# Altium schematic sheet StepGeneratorHammondFrontPnlRev1b..SchDoc(StepGeneratorHammondFrontPnlRev1b.)
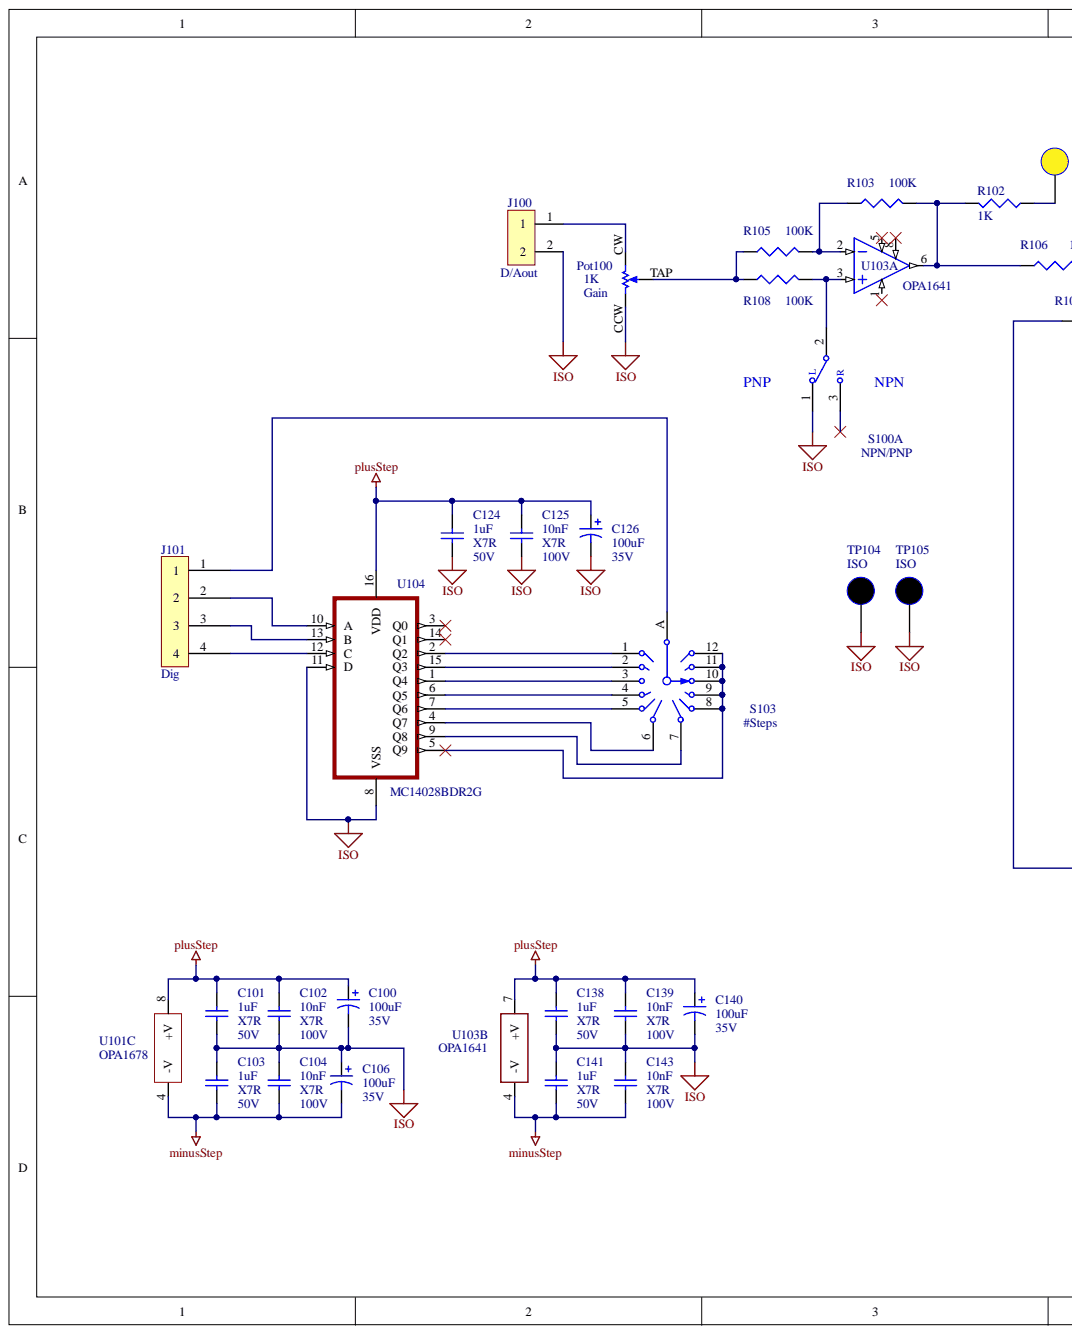
[diagram p0: StepGeneratorHammondFrontPnlRev1b..SchDoc, left]
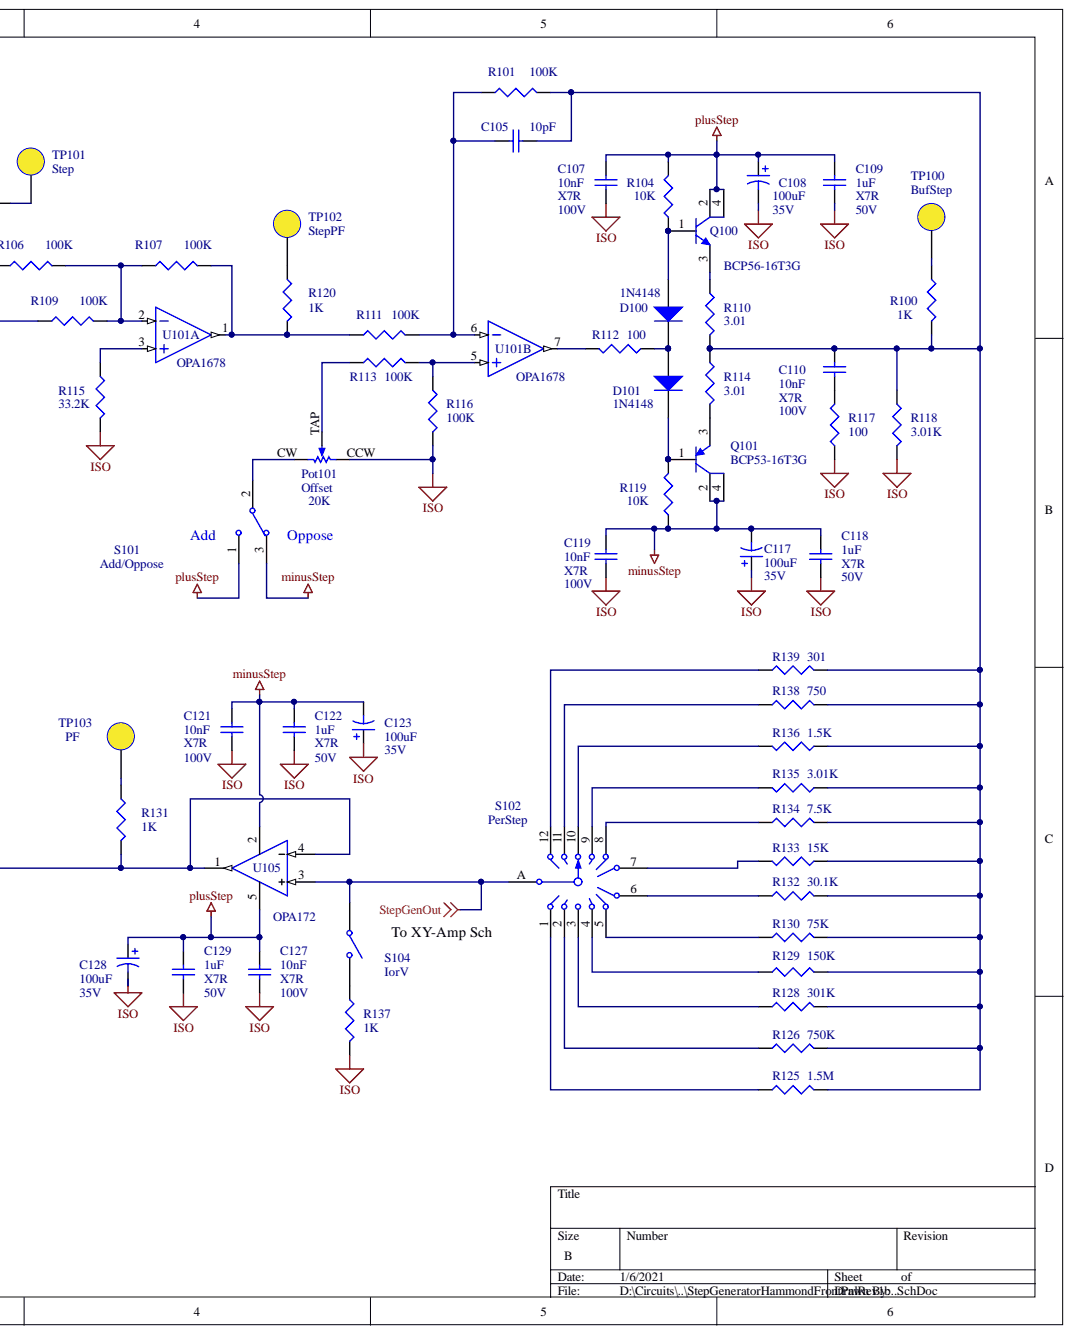
[diagram p1: StepGeneratorHammondFrontPnlRev1b..SchDoc, right]

## Parts
C100  100uF  Voltage Rating: 35V  [CAPPR2.5-6.3X10]
C101  1uF  Voltage Rating (DC): 50V  [CAPC3216M]
C102  10nF  Voltage Rating (DC): 100V  Dielectric: X7R  [CAPC3216M]
C103  1uF  Voltage Rating (DC): 50V  [CAPC3216M]
C104  10nF  Dielectric: X7R  [CAPC3216M]
C105  value 10pF  [CAPC3216M]  (hidden comment: Cap)
C106  100uF  Voltage Rating: 35V  [CAPPR2.5-6.3X10]
C107  10nF  Voltage Rating (DC): 100V  Dielectric: X7R  [CAPC3216M]
C108  100uF  Voltage Rating: 35V  [CAPPR2.5-6.3X10]
C109  1uF  Voltage Rating (DC): 50V  Dielectric: X7R  [CAPC3216M]
C110  10nF  Voltage Rating (DC): 100V  Dielectric: X7R  [CAPC3216M]
C117  100uF  Voltage Rating: 35V  [CAPPR2.5-6.3X10]
C118  1uF  Voltage Rating (DC): 50V  Dielectric: X7R  [CAPC3216M]
C119  10nF  Voltage Rating (DC): 100V  Dielectric: X7R  [CAPC3216M]
C121  10nF  Voltage Rating (DC): 100V  Dielectric: X7R  [CAPC3216M]
C122  1uF  Voltage Rating (DC): 50V  Dielectric: X7R  [CAPC3216M]
C123  100uF  Voltage Rating: 35V  [CAPPR2.5-6.3X10]
C124  1uF  Voltage Rating (DC): 50V  Dielectric: X7R  [CAPC3216M]
C125  10nF  Voltage Rating (DC): 100V  Dielectric: X7R  [CAPC3216M]
C126  100uF  Voltage Rating: 35V  [CAPPR2.5-6.3X10]
C127  10nF  Voltage Rating (DC): 100V  Dielectric: X7R  [CAPC3216M]
C128  100uF  Voltage Rating: 35V  [CAPPR2.5-6.3X10]
C129  1uF  Voltage Rating (DC): 50V  Dielectric: X7R  [CAPC3216M]
C138  1uF  Voltage Rating (DC): 50V  Dielectric: X7R  [CAPC3216M]
C139  10nF  Voltage Rating (DC): 100V  Dielectric: X7R  [CAPC3216M]
C140  100uF  Voltage Rating: 35V  [CAPPR2.5-6.3X10]
C141  1uF  Voltage Rating (DC): 50V  Dielectric: X7R  [CAPC3216M]
C143  10nF  Voltage Rating (DC): 100V  Dielectric: X7R  [CAPC3216M]
D100  1N4148  [SOD123]
D101  1N4148  [SOD123]
J100  D/Aout  [DTA-100-2]
J101  Dig  [DTA-100-4]
Pot100  Gain  value 1K  [3306P-CCW]
Pot101  Offset  value 20K  [Off-PCB]
Q100  Manufacturer Part Number: BCP56-16T3G  [SOT223-4M]  (hidden comment: NPN-2C)
Q101  Manufacturer Part Number: BCP53-16T3G  [SOT223-4M]  (hidden comment: PNP-2C)
R100  1K  [RESC3216M]
R101  100k  [RESC3216M]
R102  1K  [RESC3216M]
R103  100K  [RESC3216M]
R104  10K  [RESC3216M]
R105  100k  [RESC3216M]
R106  100k  [RESC3216M]
R107  100k  [RESC3216M]
R108  100k  [RESC3216M]
R109  100k  [RESC3216M]
R110  value 3.01  [RESC3216M]  (hidden comment: 10)
R111  100k  [RESC3216M]
R112  100  [RESC3216M]
R113  100k  [RESC3216M]
R114  value 3.01  [RESC3216M]  (hidden comment: 10)
R115  value 33.2K  [RESC3216M]  (hidden comment: 3.32KXXK)
R116  100k  [RESC3216M]
R117  100  [RESC3216M]
R118  value 3.01K  [RESC3216M]  (hidden comment: 3K)
R119  10K  [RESC3216M]
R120  1K  [RESC3216M]
R125  1.5M  [RESC3216M]
R126  750K  [RESC3216M]
R128  301K  [RESC3216M]
R129  150K  [RESC3216M]
R130  75K  [RESC3216M]
R131  1K  [RESC3216M]
R132  30.1K  [RESC3216M]
R133  15K  [RESC3216M]
R134  7.5K  [RESC3216M]
R135  value 3.01K  [RESC3216M]  (hidden comment: 3K)
R136  1.5K  [RESC3216M]
R137  1K  [RESC3216M]
R138  750  [RESC6432M]
R139  301  [RESC6432M]
S100  NPN/PNP  [EG2424]  (part A)
S101  Add/Oppose  [EG2375]
S102  PerStep  [A112]
S103  #Steps  [A112]
S104  IorV  [EG2375]
TP100  BufStep  [TP-.038]
TP101  Step  [TP-.038]
TP102  StepPF  [TP-.038]
TP103  PF  [TP-.038]
TP104  ISO  [TP-.038]
TP105  ISO  [TP-.038]
U101  OPA1678  [SOIC127P600X168_HS-8N]  (parts A,B,C)
U103  OPA1641  [SOIC127P600X168_HS-8N]  (parts A,B)
U104  MC14028BDR2G  [D16-M]
U105  OPA172  [SOT23-5AM]

## Nets
ISO: C100.2 C101.2 C102.1 C103.1 C104.2 C106.1 C107.1 C108.2 C109.2 C117.1 C118.2 C119.1 C121.1 C122.2 C123.1 C124.2 C125.1 C126.2 C127.1 C128.2 C129.2 C138.2 C139.1 C140.2 C141.1 C143.2 J100.2 Pot100.CCW Pot101.CCW R115.2 R116.2 R117.2 R118.2 R137.2 S100.1(L) TP104.1 TP105.1 U104.8(VSS) U104.11(D)
minusStep: C103.2 C104.1 C106.2 C117.2 C118.1 C119.2 C121.2 C122.1 C123.2 C141.2 C143.1 Q101.2 Q101.4 R119.2 S101.3 U101.4(-V) U103.4(-V) U105.2
plusStep: C100.1 C101.1 C102.2 C107.2 C108.1 C109.1 C124.1 C125.2 C126.1 C127.2 C128.1 C129.1 C138.1 C139.2 C140.1 Q100.2 Q100.4 R104.1 S101.1 U101.8(+V) U103.7(+V) U104.16(VDD) U105.5
StepGenOut: S102.A S104.1 U105.3
NetC105_1: C105.1 R101.1 R111.2 U101.6
NetC105_2: C105.2 C110.2 R100.2 R101.2 R110.2 R114.1 R118.1 R125.2 R126.2 R128.2 R129.2 R130.2 R132.2 R133.2 R134.2 R135.1 R136.2 R138.2 R139.2
NetC110_1: C110.1 R117.1
NetD100_1: D100.1 Q100.1 R104.2
NetD100_2: D100.2 D101.1 R112.2
NetD101_2: D101.2 Q101.1 R119.1
NetJ100_1: J100.1 Pot100.CW
NetJ101_1: J101.1 S103.A
NetJ101_2: J101.2 U104.10(A)
NetJ101_3: J101.3 U104.13(B)
NetJ101_4: J101.4 U104.12(C)
NetPot100_TAP: Pot100.TAP R105.1 R108.1
NetPot101_CW: Pot101.CW S101.2
NetPot101_TAP: Pot101.TAP R113.1
NetQ100_3: Q100.3 R110.1
NetQ101_3: Q101.3 R114.2
NetR100_1: R100.1 TP100.1
NetR102_1: R102.1 TP101.1
NetR102_2: R102.2 R103.2 R106.1 U103.6
NetR103_1: R103.1 R105.2 U103.2
NetR106_2: R106.2 R107.1 R109.2 U101.2
NetR107_2: R107.2 R111.1 R120.2 U101.1
NetR108_2: R108.2 S100.2 U103.3
NetR109_1: R109.1 R131.2 U105.1 U105.4
NetR112_1: R112.1 U101.7
NetR113_2: R113.2 R116.1 U101.5
NetR115_1: R115.1 U101.3
NetR120_1: R120.1 TP102.1
NetR125_1: R125.1 S102.1
NetR126_1: R126.1 S102.2
NetR128_1: R128.1 S102.3
NetR129_1: R129.1 S102.4
NetR130_1: R130.1 S102.5
NetR131_1: R131.1 TP103.1
NetR132_1: R132.1 S102.6
NetR133_1: R133.1 S102.7
NetR134_1: R134.1 S102.8
NetR135_2: R135.2 S102.9
NetR136_1: R136.1 S102.10
NetR137_1: R137.1 S104.2
NetR138_1: R138.1 S102.11
NetR139_1: R139.1 S102.12
NetS103_1: S103.1 U104.2(Q2)
NetS103_2: S103.2 U104.15(Q3)
NetS103_3: S103.3 U104.1(Q4)
NetS103_4: S103.4 U104.6(Q5)
NetS103_5: S103.5 U104.7(Q6)
NetS103_6: S103.6 U104.4(Q7)
NetS103_7: S103.7 U104.9(Q8)
NetS103_8: S103.8 S103.9 S103.10 S103.11 S103.12 U104.5(Q9)

Not connected: S100 3(R); U103 1 5 8; U104 3(Q0) 14(Q1)

## Library data of the parts
C100 C106 C108 C117 C123 C126 C128 C140 = Cap Pol1: CAP ALUM 100UF 20% 35V THRU HOLE; Manufacturer Part Number: 35YXJ100M6.3X11; Manufacturer: Rubycon; Ripple Current: 400mA; Polarity: Polar; Life (Hours): 7000Hour; Leakage Current: 3uA; Lead Diameter: 0.5mm; Impedance: 220mR; Voltage: 35V; Value: 100uF; Tolerance: 20%; Termination: Radial; Supplier Part Number: 1189-2260-ND; Supplier: Digi-Key; Simulation: CAP; Signal Integrity: Cap Pol; Radiation Hardening: No; Packaging: Bulk; Package Quantity: 3000; Mount: Through Hole; Min Operating Temperature: -40°C; Max Operating Temperature: 105°C; Library Name: Miscellaneous Devices.IntLib; Lead Pitch: 2.5mm; Height - Seated (Max): 0.492inch; Height: 11mm; Diameter: 6.3mm; Component Kind: Standard; Case/Package: Radial; Capacitance: 100uF
C101 C103 C109 C118 C122 C124 C129 C138 C141 = Cap: CAP CER 1UF 50V X7R 1206; Manufacturer Part Number: C1206C105K5RACTU; Manufacturer: KEMET; Tolerance: 10%; Dielectric Material: Ceramic; Width: 0.063inch; Voltage Rating: 50V; Voltage: 50V; Value: 1uF; Thickness: 0.043inch; Termination: SMD/SMT; Supplier Part Number: 399-8147-6-ND; Supplier: Digi-Key; Simulation: CAP; Signal Integrity: Cap; Series: C; REACH SVHC: No SVHC; Radiation Hardening: No; Packaging: Tape and Reel; Package Quantity: 1; Number of Pins: 2; Mount: Surface Mount; Min Operating Temperature: -55°C; Max Operating Temperature: 125°C; Library Name: Miscellaneous Devices.IntLib; Length: 3.2mm; Height: 1mm; Depth: 1.6mm; Construction: Flat; Component Kind: Standard; Case Code (Metric): 3216; Case Code (Imperial): 1206; Case/Package: 1206; Capacitance: 1uF
  C101 C103: Dielectric: X7R
C102 C104 C107 C110 C119 C121 C125 C127 C139 C143 = Cap: CAP CER 10NF 100V X7R 1206; Manufacturer Part Number: C1206C103K1RACTU; Manufacturer: KEMET; Tolerance: 10%; Dissipation Factor: 2.5%; Dielectric Material: Ceramic; Width: 0.063inch; Voltage Rating: 100V; Voltage: 100V; Value: 10nF; Thickness: 0.035inch; Termination: SMD/SMT; Supplier Part Number: 399-1236-6-ND; Supplier: Digi-Key; Simulation: CAP; Signal Integrity: Cap; Series: C; REACH SVHC: No SVHC; Radiation Hardening: No; Packaging: Tape and Reel; Package Quantity: 1; Number of Pins: 2; Mount: Surface Mount,PCB; Min Operating Temperature: -55°C; Max Operating Temperature: 125°C; Library Name: Miscellaneous Devices.IntLib; Length: 3.2mm; Height: 0.78mm; Depth: 1.6mm; Contact Plating: Tin,Matte; Construction: Flat; Component Kind: Standard; Case Code (Metric): 3216; Case Code (Imperial): 1206; Case/Package: 1206; Capacitance: 10nF
  C104: Voltage Rating (DC): 100V
C105 = Cap: CAP CER 10PF 100V NP0 1206; Manufacturer Part Number: C1206C100J1GACTU; Manufacturer: Kemet; Tolerance: ±5%; Temperature Coefficient: COG; Voltage - Rated: 100V; Thickness (Max): 0.035" (0.88mm); Supplier Part Number: 399-8124-1-ND; Supplier: Digi-Key; Size / Dimension: 0.126" L x 0.063" W (3.20mm x 1.60mm); Simulation: CAP; Signal Integrity: Cap; RoHS: RoHS Compliant; Packaging: Cut Tape (CT); Package / Case: 1206 (3216 Metric); Operating Temperature: -55°C ~ 125°C; Mounting Type: Surface Mount, MLCC; Library Name: Miscellaneous Devices.IntLib; Component Kind: Standard; Category: Capacitors; Capacitance: 10pF; Applications: General Purpose
D100 D101 = Diode 1N4148: DIODE GP 75V 150MA SOD123FL; Manufacturer Part Number: 1N4148WFL-G3-08; Manufacturer: Vishay Semiconductor Diodes Division; Voltage - Forward (Vf) (Max) @ If: 1.2V @ 100mA; Speed: Small Signal =< 200mA (Io), Any Speed; Reverse Recovery Time (trr): 4ns; Diode Type: Standard; Current - Reverse Leakage @ Vr: 5µA @ 75V; Voltage - DC Reverse (Vr) (Max): 75V; Value: 1N4148; Supplier Part Number: 1N4148WFL-G3-08GICT-ND; Supplier Device Package: SOD-123FL; Supplier: Digi-Key; Simulation: 1N4148; Signal Integrity: 1N4148; RoHS: RoHS Compliant; Published: 8-Jun-2000; Packaging: Cut Tape (CT); PackageReference: DO-35; PackageDescription: Diode, Axial; Body 3.8 x 1.9 mm (LxDia. max), Lead Dia. 0.53 mm (max); Package / Case: SOD-123F; Operating Temperature - Junction: 150°C (Max); Mounting Type: Surface Mount; Library Name: Miscellaneous Devices.IntLib; LatestRevisionNote: Re-released for DXP Platform.; LatestRevisionDate: 17-Jul-2002; DatasheetVersion: 1997; Current - Average Rectified (Io): 150mA; ComponentLink2URL: http://www.fairchildsemi.com/ds/1N%2F1N4148.pdf; ComponentLink2Description: Datasheet; ComponentLink1URL: http://www.fairchildsemi.com/; ComponentLink1Description: Manufacturer Link; Component Kind: Standard; Code_JEDEC: DO-204-AH; Category: Discrete Semiconductor Products; Publisher: Altium Limited
J100 = 2-Pin: CONN HEADER VERT 2POS 2.54MM; Manufacturer: TE Connectivity; Working Voltage: 250V; Value: 640456-2; Tail Length: 0.14inch; Strain Relief: No (Without); Plating Thickness: 3.81um; PCB Thickness: 0.063inch; PCB Mount Retention: Without; PCB Mounting Orientation: Vertical; Number of Positions: 2; Mating Connector Lock: Yes (With); Gender: Male; Current Rating: 5A; Contact Style: Straight; Contact Resistance: 6mR; Contact Post Length: 3.56mm; Connector Type: Connector,Pin,Plug,Header; Connector System: Wire-to-Board; Circuit Application: Signal; Approvals: CSA; Voltage Rating (AC): 250V; Voltage Rating: 250V; Termination: Solder; Supplier Part Number: A1921-ND; Supplier: Digi-Key; Shrouded: No (Without); Sealable: No (Without); REACH SVHC: No SVHC; Radiation Hardening: No; Pitch: 2.54mm; PCB Mount Alignment: No (Without); Panel Mount Retention: No (Without); Packaging: Bulk; Package Quantity: 5000; Orientation: Straight; Number of Rows: 1; Number of Poles: 2; Number of Contacts: 2; Mounting Ears: No (Without); Mount: Through Hole; Min Operating Temperature: -67°C; Max Voltage Rating (AC): 250V; Max Operating Temperature: 221°C; Mating Retention: No (Without); Mating Alignment: No (Without); Manufacturer Part Number: 640456-2; Library Name: MY_CONNECTORS.SchLib; Length: 7.62mm; Lead Pitch: 2.54mm; Lead Free: Lead Free; Housing Material: Polyester; Housing Color: Natural; Height: 7.87mm; Flammability Rating: UL94 V-0; Fastening Type: Friction; ELV: Compliant; Depth: 5.72mm; Contact Shape: Square; Contact Retention: No (Without); Contact Plating: Tin; Contact Mating Length: 7.49mm; Contact Material: Copper Alloy; Contact Gender: Male; Contact Current Rating: 5A; Component Kind: Standard; Color: Natural,White,Beige; Backwall/Post Interruptions: No (Without)
J101 = 4-Pin: CONN HEADER VERT 4POS 2.54MM; Manufacturer: TE Connectivity; Working Voltage: 250V; Value: 640456-4; Tail Length: 0.14inch; Strain Relief: No (Without); Plating Thickness: 3.81um; PCB Thickness: 0.063inch; PCB Mount Retention: Without; PCB Mounting Orientation: Vertical; Number of Positions: 4; Mating Connector Lock: Yes (With); Current Rating: 5A; Contact Style: Straight; Contact Resistance: 6mR; Contact Post Length: 3.56mm; Connector Type: Connector,Pin,Plug,Header; Connector System: Wire-to-Board; Circuit Application: Signal; Approvals: CSA; Voltage Rating (AC): 250V; Voltage Rating: 250V; Termination: Solder; Supplier Part Number: A1922-ND; Supplier: Digi-Key; Shrouded: No (Without); Sealable: No (Without); REACH SVHC: No SVHC; Radiation Hardening: No; Pitch: 2.54mm; PCB Mount Alignment: No (Without); Panel Mount Retention: No (Without); Packaging: Bulk; Package Quantity: 5000; Orientation: Straight; Number of Rows: 1; Number of Poles: 4; Number of Contacts: 4; Mounting Ears: No (Without); Mount: Through Hole; Min Operating Temperature: -67°C; Max Voltage Rating (AC): 250V; Max Operating Temperature: 221°C; Mating Retention: No (Without); Mating Alignment: No (Without); Manufacturer Part Number: 640456-4; Library Name: MY_CONNECTORS.SchLib; Length: 12.7mm; Lead Pitch: 2.54mm; Housing Material: Polyester; Housing Color: Natural; Height: 7.87mm; Flammability Rating: UL94 V-0; Fastening Type: Friction; Depth: 5.72mm; Contact Shape: Square; Contact Retention: No (Without); Contact Plating: Tin; Contact Mating Length: 7.49mm; Contact Material: Copper Alloy; Contact Gender: Male; Contact Current Rating: 5A; Component Kind: Standard; Color: Natural,Beige,White; Backwall/Post Interruptions: No (Without)
Pot100 = POT-Single: TRIMMER 1K OHM 0.2W PC PIN TOP; Manufacturer Part Number: 3306P-1-102; Manufacturer: Bourns; Voltage Rating: 100V; Tolerance: 25%; Temperature Coefficient: 250ppm/°C; Resistance: 1kR; Power Rating: 200mW; Pitch: 2.5mm; Number of Turns: 1; Width: 6.81mm; Weight: 360mg; Termination: Pin; Taper: Linear; Supplier Part Number: 3306P-102-ND; Supplier: Digi-Key; REACH SVHC: No SVHC; Radiation Hardening: No; Packaging: Bulk; Package Quantity: 200; Mount: Through Hole,PCB; Min Operating Temperature: -25°C; Military Standard: Not; Max Power Dissipation: 200mW; Max Operating Temperature: 100°C; Length: 6.81mm; Height: 7.62mm; Diameter: 6.81mm; Depth: 8.1mm; Composition: Cermet; Component Kind: Standard; Color: Blue; Library Name: MY_POTS.SchLib
Pot101 = POT-Single: PNL MNT 20K OHM 0.125W; Manufacturer Part Number: RV16AF-10-15R1-B20K-3LA; Manufacturer: Alpha Taiwan; Supplier Part Number: 313-1000F-20K; Supplier: Mouser; Component Kind: Standard; Library Name: MY_POTS.SchLib
Q100 = NPN-2C: TRANS NPN 80V 1A SOT-223; Manufacturer: ON Semiconductor; Vce Saturation (Max) @ Ib, Ic: 500mV @ 50mA, 500mA; Value: BCP56; Power - Max: 1.5W; Frequency - Transition: 130MHz; DC Current Gain (hFE) (Min) @ Ic, Vce: 100 @ 150mA, 2V; Current - Collector Cutoff (Max): 100nA (ICBO); Voltage - Collector Emitter Breakdown (Max): 80V; Transistor Type: NPN; Supplier Part Number: BCP56-16T3GOSCT-ND; Supplier Device Package: SOT-223; Supplier: Digi-Key; RoHS: RoHS Compliant; Part Status: Active; Packaging: Cut Tape (CT); Package / Case: TO-261-4, TO-261AA; Operating Temperature: -65°C ~ 150°C (TJ); Mounting Type: Surface Mount; Library Name: My_Miscellaneous Devices.SchLib; Current - Collector (Ic) (Max): 1A; Component Kind: Standard; Category: Discrete Semiconductor Products
Q101 = PNP-2C: TRANS PNP 80V 1.5A SOT-223; Manufacturer: ON Semiconductor; Vce Saturation (Max) @ Ib, Ic: 500mV @ 50mA, 500mA; Value: BCP53; Power - Max: 1.5W; Frequency - Transition: 50MHz; DC Current Gain (hFE) (Min) @ Ic, Vce: 100 @ 150mA, 2V; Current - Collector Cutoff (Max): 100nA (ICBO); Voltage - Collector Emitter Breakdown (Max): 80V; Transistor Type: PNP; Supplier Part Number: BCP53-16T3GOSCT-ND; Supplier Device Package: SOT-223; Supplier: Digi-Key; RoHS: RoHS Compliant; Part Status: Active; Packaging: Cut Tape (CT); Package / Case: TO-261-4, TO-261AA; Operating Temperature: -65°C ~ 150°C (TJ); Mounting Type: Surface Mount; Library Name: My_Miscellaneous Devices.SchLib; Current - Collector (Ic) (Max): 1.5A; Component Kind: Standard; Category: Discrete Semiconductor Products
R100 R102 R120 R131 R137 = Res3: RES 1K OHM 1% 1/4W 1206; Manufacturer Part Number: RMCF1206FT1K00; Manufacturer: Stackpole Electronics; Temperature Coefficient: 100ppm/°C; Resistance: 1kR; Power Rating: 250mW; Width: 0.063inch; Value: 1K; Tolerance: 1%; Supplier Part Number: RMCF1206FT1K00CT-ND; Supplier: Arrow; Simulation: RESISTOR; Signal Integrity: Res; Radiation Hardening: No; Packaging: Tape and Reel; Number of Terminations: 2; Mount: Surface Mount; Min Operating Temperature: -55°C; Military Standard: Not; Max Power Dissipation: 250mW; Max Operating Temperature: 155°C; Library Name: Miscellaneous Devices.IntLib; Length: 3.2mm; Lead Free: Lead Free; Height: 0.028inch; Features: Automotive AEC-Q200; Depth: 1.6mm; Contact Plating: Matte,Tin; Composition: Thick Film; Component Kind: Standard; Case Code (Metric): 3216; Case Code (Imperial): 1206; Case/Package: 1206
R101 R105-R109 R111 R113 R116 = Res3: RES 100K OHM 1% 1/4W 1206; Manufacturer Part Number: RMCF1206FT100K; Manufacturer: Stackpole Electronics; Temperature Coefficient: 100ppm/°C; Resistance: 100kR; Power Rating: 250mW; Width: 0.063inch; Value: 100K; Tolerance: 1%; Supplier Part Number: RMCF1206FT100KCT-ND; Supplier: Digi-Key; Simulation: RESISTOR; Signal Integrity: Res; Radiation Hardening: No; Packaging: Tape and Reel; Number of Terminations: 2; Mount: Surface Mount; Min Operating Temperature: -55°C; Military Standard: Not; Max Power Dissipation: 250mW; Max Operating Temperature: 155°C; Library Name: Miscellaneous Devices.IntLib; Length: 0.126inch; Lead Free: Lead Free; Height: 0.028inch; Features: Automotive AEC-Q200; Depth: 1.6mm; Contact Plating: Matte,Tin; Composition: Thick Film; Component Kind: Standard; Case Code (Metric): 3216; Case Code (Imperial): 1206; Case/Package: 1206
R103 = Res3: RES 100K OHM 1% 1/4W 1206; Manufacturer Part Number: RMCF1206FT100K; Manufacturer: Stackpole Electronics; Temperature Coefficient: 100ppm/°C; Resistance: 100kR; Max Power Dissipation: 250mW; Width: 0.063inch; Value: 100K; Tolerance: 1%; Supplier Part Number: RMCF1206FT100KCT-ND; Supplier: Digi-Key; Simulation: RESISTOR; Signal Integrity: Res; Radiation Hardening: No; Packaging: Tape and Reel; Number of Terminations: 2; Mount: Surface Mount; Min Operating Temperature: -55°C; Military Standard: Not; Max Operating Temperature: 155°C; Library Name: Miscellaneous Devices.IntLib; Length: 3.2mm; Lead Free: Lead Free; Height: 0.028inch; Features: Automotive AEC-Q200; Depth: 1.6mm; Contact Plating: Matte,Tin; Composition: Thick Film; Component Kind: Standard; Case Code (Metric): 3216; Case Code (Imperial): 1206; Case/Package: 1206
R104 R119 = Res3: RES 10K OHM 1% 1/4W 1206; Manufacturer Part Number: RMCF1206FT10K0; Manufacturer: Stackpole Electronics; Voltage Rating (DC): 200V; Temperature Coefficient: 100ppm/°C; Resistance: 10kR; Power Rating: 250mW; Width: 0.063inch; Voltage Rating: 200V; Value: 10K; Tolerance: 1%; Termination: SMD/SMT; Supplier Part Number: RMCF1206FT10K0CT-ND; Supplier: Digi-Key; Simulation: RESISTOR; Signal Integrity: Res; REACH SVHC: No SVHC; Radiation Hardening: No; Packaging: Tape and Reel; Package Quantity: 1; Number of Terminations: 2; Number of Pins: 2; Mount: Surface Mount; Min Operating Temperature: -55°C; Military Standard: Not; Max Power Dissipation: 250mW; Max Operating Temperature: 155°C; Library Name: Miscellaneous Devices.IntLib; Length: 3.2mm; Lead Free: Lead Free; Height: 0.028inch; Features: Automotive AEC-Q200; Depth: 1.6mm; Contact Plating: Matte,Tin; Composition: Thick Film; Component Kind: Standard; Case Code (Metric): 3216; Case Code (Imperial): 1206; Case/Package: 1206
R110 R114 = Res3: RES 3.01 OHM 1% 1/4W 1206; Manufacturer Part Number: RMCF1206FT3R01; Manufacturer: Stackpole Electronics; Temperature Coefficient: 200ppm/°C; Resistance: 3R01; Power Rating: 250mW; Width: 0.063inch; Tolerance: 1%; Supplier Part Number: RMCF1206FT3R01CT-ND; Supplier: Digi-Key; Simulation: RESISTOR; Signal Integrity: Res; Radiation Hardening: No; Packaging: Tape and Reel; Number of Terminations: 2; Mount: Surface Mount; Min Operating Temperature: -55°C; Military Standard: Not; Max Power Dissipation: 250mW; Max Operating Temperature: 155°C; Library Name: Miscellaneous Devices.IntLib; Length: 3.2mm; Lead Free: Lead Free; Height: 0.028inch; Features: Automotive AEC-Q200; Depth: 1.6mm; Contact Plating: Tin,Matte; Composition: Thick Film; Component Kind: Standard; Case Code (Metric): 3216; Case Code (Imperial): 1206; Case/Package: 1206
R112 R117 = Res3: RES 100 OHM 1% 1/4W 1206; Manufacturer Part Number: RMCF1206FT100R; Manufacturer: Stackpole Electronics; Temperature Coefficient: 100ppm/°C; Resistance: 100R; Power Rating: 250mW; Width: 0.063inch; Value: 100; Tolerance: 1%; Supplier Part Number: RMCF1206FT100RCT-ND; Supplier: Digi-Key; Simulation: RESISTOR; Signal Integrity: Res; Radiation Hardening: No; Packaging: Tape and Reel; Number of Terminations: 2; Mount: Surface Mount; Min Operating Temperature: -55°C; Military Standard: Not; Max Power Dissipation: 250mW; Max Operating Temperature: 155°C; Library Name: Miscellaneous Devices.IntLib; Length: 0.126inch; Lead Free: Lead Free; Height: 0.028inch; Features: Automotive AEC-Q200; Depth: 1.6mm; Contact Plating: Matte,Tin; Composition: Thick Film; Component Kind: Standard; Case Code (Metric): 3216; Case Code (Imperial): 1206; Case/Package: 1206
R115 = Res3: RES 33.2K OHM 1% 1/4W 1206; Manufacturer Part Number: RMCF1206FT33K2; Manufacturer: Stackpole Electronics; Temperature Coefficient: 100ppm/°C; Resistance: 33.2kR; Power Rating: 250mW; Width: 0.063inch; Tolerance: 1%; Supplier Part Number: RMCF1206FT33K2CT-ND; Supplier: Digi-Key; Simulation: RESISTOR; Signal Integrity: Res; Radiation Hardening: No; Packaging: Tape and Reel; Number of Terminations: 2; Mount: Surface Mount; Min Operating Temperature: -55°C; Military Standard: Not; Max Power Dissipation: 250mW; Max Operating Temperature: 155°C; Library Name: Miscellaneous Devices.IntLib; Length: 0.126inch; Lead Free: Lead Free; Height: 0.028inch; Features: Automotive AEC-Q200; Depth: 1.6mm; Contact Plating: Matte,Tin; Composition: Thick Film; Component Kind: Standard; Case Code (Metric): 3216; Case Code (Imperial): 1206; Case/Package: 1206
R118 R135 = Res3: RES  3.01K OHM 1% 1/4W 1206; Manufacturer Part Number: RMCF1206FT3K01; Manufacturer: Stackpole Electronics; Temperature Coefficient: 100ppm/°C; Resistance: 3.01kR; Power Rating: 250mW; Width: 0.063inch; Tolerance: 1%; Supplier Part Number: RMCF1206FT3K01CT-ND; Supplier: Digi-Key; Simulation: RESISTOR; Signal Integrity: Res; Radiation Hardening: No; Packaging: Tape and Reel; Number of Terminations: 2; Mount: Surface Mount; Min Operating Temperature: -55°C; Military Standard: Not; Max Power Dissipation: 250mW; Max Operating Temperature: 155°C; Library Name: Miscellaneous Devices.IntLib; Length: 0.126inch; Lead Free: Lead Free; Height: 0.028inch; Features: Automotive AEC-Q200; Depth: 1.6mm; Contact Plating: Matte,Tin; Composition: Thick Film; Component Kind: Standard; Case Code (Metric): 3216; Case Code (Imperial): 1206; Case/Package: 1206
R125 = Res3: RES 1.5M OHM 1% 1/4W 1206; Manufacturer Part Number: RMCF1206FT1M50; Manufacturer: Stackpole Electronics; Temperature Coefficient: 100ppm/°C; Resistance: 1.5MR; Power Rating: 250mW; Width: 0.063inch; Value: 1.5M; Tolerance: 1%; Supplier Part Number: RMCF1206FT1M50CT-ND; Supplier: Digi-Key; Simulation: RESISTOR; Signal Integrity: Res; Radiation Hardening: No; Packaging: Tape and Reel; Number of Terminations: 2; Mount: Surface Mount; Min Operating Temperature: -55°C; Military Standard: Not; Max Power Dissipation: 250mW; Max Operating Temperature: 155°C; Library Name: Miscellaneous Devices.IntLib; Length: 0.126inch; Lead Free: Lead Free; Height: 0.028inch; Features: Automotive AEC-Q200; Depth: 1.6mm; Contact Plating: Matte,Tin; Composition: Thick Film; Component Kind: Standard; Case Code (Metric): 3216; Case Code (Imperial): 1206; Case/Package: 1206
R126 = Res3: RES 750K OHM 1% 1/4W 1206; Manufacturer Part Number: RMCF1206FT750K; Manufacturer: Stackpole Electronics; Temperature Coefficient: 100ppm/°C; Resistance: 750kR; Power Rating: 250mW; Width: 0.063inch; Value: 750K; Tolerance: 1%; Supplier Part Number: RMCF1206FT750KCT-ND; Supplier: Digi-Key; Simulation: RESISTOR; Signal Integrity: Res; Radiation Hardening: No; Packaging: Tape and Reel; Number of Terminations: 2; Mount: Surface Mount; Min Operating Temperature: -55°C; Military Standard: Not; Max Power Dissipation: 250mW; Max Operating Temperature: 155°C; Library Name: Miscellaneous Devices.IntLib; Length: 0.126inch; Lead Free: Lead Free; Height: 0.028inch; Features: Automotive AEC-Q200; Depth: 1.6mm; Contact Plating: Tin,Matte; Composition: Thick Film; Component Kind: Standard; Case Code (Metric): 3216; Case Code (Imperial): 1206; Case/Package: 1206
R128 = Res3: RES 301K OHM 1% 1/4W 1206; Manufacturer Part Number: RMCF1206FT301K; Manufacturer: Stackpole Electronics; Temperature Coefficient: 100ppm/°C; Resistance: 301kR; Power Rating: 250mW; Width: 0.063inch; Value: 301K; Tolerance: 1%; Supplier Part Number: RMCF1206FT301KCT-ND; Supplier: Digi-Key; Simulation: RESISTOR; Signal Integrity: Res; Radiation Hardening: No; Packaging: Tape and Reel; Number of Terminations: 2; Mount: Surface Mount; Min Operating Temperature: -55°C; Military Standard: Not; Max Power Dissipation: 250mW; Max Operating Temperature: 155°C; Library Name: Miscellaneous Devices.IntLib; Length: 0.126inch; Lead Free: Lead Free; Height: 0.028inch; Features: Automotive AEC-Q200; Depth: 1.6mm; Contact Plating: Tin,Matte; Composition: Thick Film; Component Kind: Standard; Case Code (Metric): 3216; Case Code (Imperial): 1206; Case/Package: 1206
R129 = Res3: RES 150K OHM 1% 1/4W 1206; Manufacturer Part Number: RMCF1206FT150K; Manufacturer: Stackpole Electronics; Temperature Coefficient: 100ppm/°C; Resistance: 150kR; Power Rating: 250mW; Width: 0.063inch; Value: 150K; Tolerance: 1%; Supplier Part Number: RMCF1206FT150KCT-ND; Supplier: Digi-Key; Simulation: RESISTOR; Signal Integrity: Res; Radiation Hardening: No; Packaging: Tape and Reel; Number of Terminations: 2; Mount: Surface Mount; Min Operating Temperature: -55°C; Military Standard: Not; Max Power Dissipation: 250mW; Max Operating Temperature: 155°C; Library Name: Miscellaneous Devices.IntLib; Length: 0.126inch; Lead Free: Lead Free; Height: 0.028inch; Features: Automotive AEC-Q200; Depth: 1.6mm; Contact Plating: Matte,Tin; Composition: Thick Film; Component Kind: Standard; Case Code (Metric): 3216; Case Code (Imperial): 1206; Case/Package: 1206
R130 = Res3: RES 75K OHM 1% 1/4W 1206; Manufacturer Part Number: RMCF1206FT75K0; Manufacturer: Stackpole Electronics; Temperature Coefficient: 100ppm/°C; Resistance: 75KR; Power Rating: 250mW; Width: 0.063inch; Value: 75K; Tolerance: 1%; Supplier Part Number: RMCF1206FT75K0CT-ND; Supplier: Digi-Key; Simulation: RESISTOR; Signal Integrity: Res; Radiation Hardening: No; Packaging: Tape and Reel; Number of Terminations: 2; Mount: Surface Mount; Min Operating Temperature: -55°C; Military Standard: Not; Max Power Dissipation: 250mW; Max Operating Temperature: 155°C; Library Name: Miscellaneous Devices.IntLib; Length: 0.126inch; Lead Free: Lead Free; Height: 0.028inch; Features: Automotive AEC-Q200; Depth: 1.6mm; Contact Plating: Matte,Tin; Composition: Thick Film; Component Kind: Standard; Case Code (Metric): 3216; Case Code (Imperial): 1206; Case/Package: 1206
R132 = Res3: RES 30.1K OHM 1% 1/4W 1206; Manufacturer Part Number: RMCF1206FT30K1; Manufacturer: Stackpole Electronics; Temperature Coefficient: 100ppm/°C; Resistance: 30.1kR; Power Rating: 250mW; Width: 0.063inch; Value: 30.1K; Tolerance: 1%; Supplier Part Number: RMCF1206FT30K0CT-ND; Supplier: Digi-Key; Simulation: RESISTOR; Signal Integrity: Res; Radiation Hardening: No; Packaging: Tape and Reel; Number of Terminations: 2; Mount: Surface Mount; Min Operating Temperature: -55°C; Military Standard: Not; Max Power Dissipation: 250mW; Max Operating Temperature: 155°C; Library Name: Miscellaneous Devices.IntLib; Length: 0.126inch; Lead Free: Lead Free; Height: 0.028inch; Features: Automotive AEC-Q200; Depth: 1.6mm; Contact Plating: Matte,Tin; Composition: Thick Film; Component Kind: Standard; Case Code (Metric): 3216; Case Code (Imperial): 1206; Case/Package: 1206
R133 = Res3: RES 15K OHM 1% 1/4W 1206; Manufacturer Part Number: RMCF1206FT15K0; Manufacturer: Stackpole Electronics; Voltage Rating (DC): 200V; Temperature Coefficient: 100ppm/°C; Resistance: 15kR; Power Rating: 250mW; Width: 0.063inch; Voltage Rating: 200V; Value: 15K; Tolerance: 1%; Termination: SMD/SMT; Supplier Part Number: RMCF1206FT15K0CT-ND; Supplier: Digi-Key; Simulation: RESISTOR; Signal Integrity: Res; REACH SVHC: No SVHC; Radiation Hardening: No; Packaging: Tape and Reel; Package Quantity: 1; Number of Terminations: 2; Number of Pins: 2; Mount: Surface Mount; Min Operating Temperature: -55°C; Military Standard: Not; Max Power Dissipation: 250mW; Max Operating Temperature: 155°C; Library Name: Miscellaneous Devices.IntLib; Length: 3.2mm; Lead Free: Lead Free; Height: 0.028inch; Features: Automotive AEC-Q200; Depth: 1.6mm; Contact Plating: Matte,Tin; Composition: Thick Film; Component Kind: Standard; Case Code (Metric): 3216; Case Code (Imperial): 1206; Case/Package: 1206
R134 = Res3: RES 7.50K OHM 1% 1/4W 1206; Manufacturer Part Number: RMCF1206FT7K50; Manufacturer: Stackpole Electronics; Temperature Coefficient: 100ppm/°C; Resistance: 7.5kR; Power Rating: 250mW; Width: 0.063inch; Value: 7.5K; Tolerance: 1%; Supplier Part Number: RMCF1206FT7K50CT-ND; Supplier: Digi-Key; Simulation: RESISTOR; Signal Integrity: Res; Radiation Hardening: No; Packaging: Tape and Reel; Number of Terminations: 2; Mount: Surface Mount; Min Operating Temperature: -55°C; Military Standard: Not; Max Power Dissipation: 250mW; Max Operating Temperature: 155°C; Library Name: Miscellaneous Devices.IntLib; Length: 0.126inch; Lead Free: Lead Free; Height: 0.028inch; Features: Automotive AEC-Q200; Depth: 1.6mm; Contact Plating: Matte,Tin; Composition: Thick Film; Component Kind: Standard; Case Code (Metric): 3216; Case Code (Imperial): 1206; Case/Package: 1206
R136 = Res3: RES 1.5K OHM 1% 1/4W 1206; Manufacturer Part Number: RMCF1206FT1K50; Manufacturer: Stackpole Electronics; Temperature Coefficient: 100ppm/°C; Resistance: 1.5KR; Power Rating: 250mW; Width: 0.063inch; Value: 1.5K; Tolerance: 1%; Supplier Part Number: RMCF1206FT1K50CT-ND; Supplier: Digi-Key; Simulation: RESISTOR; Signal Integrity: Res; Radiation Hardening: No; Packaging: Tape and Reel; Package Quantity: 1; Number of Terminations: 2; Mount: Surface Mount; Min Operating Temperature: -55°C; Military Standard: Not; Max Power Dissipation: 250mW; Max Operating Temperature: 155°C; Library Name: Miscellaneous Devices.IntLib; Length: 0.126inch; Lead Free: Lead Free; Height: 0.028inch; Features: Automotive AEC-Q200; Depth: 1.6mm; Contact Plating: Matte,Tin; Composition: Thick Film; Component Kind: Standard; Case Code (Metric): 3216; Case Code (Imperial): 1206; Case/Package: 1206
R138 = Res3: RES 750 OHM 1% 1/4W 1206; Manufacturer Part Number: RMCF1206FT750R; Manufacturer: Stackpole Electronics; Temperature Coefficient: 100ppm/°C; Resistance: 750R; Power Rating: 250mW; Width: 0.063inch; Value: 750; Tolerance: 1%; Supplier Part Number: RMCF1206FT750RCT-ND; Supplier: Digi-Key; Simulation: RESISTOR; Signal Integrity: Res; Radiation Hardening: No; Packaging: Tape and Reel; Number of Terminations: 2; Mount: Surface Mount; Min Operating Temperature: -55°C; Military Standard: Not; Max Power Dissipation: 250mW; Max Operating Temperature: 155°C; Library Name: Miscellaneous Devices.IntLib; Length: 0.126inch; Lead Free: Lead Free; Height: 0.028inch; Features: Automotive AEC-Q200; Depth: 1.6mm; Contact Plating: Tin,Matte; Composition: Thick Film; Component Kind: Standard; Case Code (Metric): 3216; Case Code (Imperial): 1206; Case/Package: 1206
R139 = Res3: RES 301 OHM 1% 1/4W 1206; Manufacturer Part Number: RMCF1206FT301R; Manufacturer: Stackpole Electronics; Temperature Coefficient: 100ppm/°C; Resistance: 301R; Power Rating: 250mW; Width: 0.063inch; Value: 301; Tolerance: 1%; Supplier Part Number: RMCF1206FT301RCT-ND; Supplier: Digi-Key; Simulation: RESISTOR; Signal Integrity: Res; Radiation Hardening: No; Packaging: Tape and Reel; Number of Terminations: 2; Mount: Surface Mount; Min Operating Temperature: -55°C; Military Standard: Not; Max Power Dissipation: 250mW; Max Operating Temperature: 155°C; Library Name: Miscellaneous Devices.IntLib; Length: 0.126inch; Lead Free: Lead Free; Height: 0.028inch; Features: Automotive AEC-Q200; Depth: 1.6mm; Contact Plating: Matte,Tin; Composition: Thick Film; Component Kind: Standard; Case Code (Metric): 3216; Case Code (Imperial): 1206; Case/Package: 1206
S100 = 3PDT: SWITCH TOGGLE 3PDT 5A 120V; Manufacturer Part Number: 1003P1T1B1M2QEH; Manufacturer: E-Switch; Voltage Rating (DC): 28V; Value: EG2424; Switch Function: On-On; Illumination Type: Non-Illuminated,Illuminated; Electrical Life: 40000Cycles; Actuator Type: Standard Round; Actuator Material: Brass,Chrome; Actuator Length: 10.41mm; Width: 16.5mm; Voltage Rating (AC): 120V; Throw Configuration: 3PDT; Termination: PC Pin; Supplier Part Number: EG2425-ND; Supplier: Digi-Key; Series: 100; Packaging: Bulk; Package Quantity: 1; Orientation: Vertical; Mount: Panel; Min Operating Temperature: -30°C; Max Operating Temperature: 85°C; Library Name: MY_SWITCH.SchLib; Length: 12.7mm; Lead Free: Lead Free; Current Rating: 5A; Contact Plating: Silver; Contact Material: Copper,Silver; Component Kind: Standard
S101 = SW-SPDT: SWITCH TOGGLE SPDT 5A 120V Center Off; Manufacturer Part Number: 100SP3T1B1M1QEH; Manufacturer: E-Switch; Voltage Rating (DC): 28V; Value: EG2375; Switch Function: On-Off-On; Max Voltage Rating (AC): 250V; Illumination Type: Non-Illuminated,Illuminated; Electrical Life: 40000Cycles; Contact Resistance: 100mR; Actuator Type: Standard Round; Actuator Material: Brass,Chrome; Actuator Length: 10.41mm; Voltage Rating (AC): 120V; Throw Configuration: SPDT; Termination: Solder; Supplier Part Number: EG2375-ND; Supplier: Digi-Key; Packaging: Bulk; Package Quantity: 1; Orientation: Straight; Mount: Panel; Min Operating Temperature: -30°C; Max Voltage Rating (DC): 28V; Max Operating Temperature: 85°C; Library Name: Miscellaneous Devices.IntLib; Lead Free: Lead Free; Housing Material: Stainless Steel; Current Rating: 5A; Contact Plating: Silver; Contact Material: Copper Alloy,Silver; ComponentLink1URL: http://www.apem.com; ComponentLink1Description: Manufacturer Link; Component Kind: Standard
S102 S103 = 12Way-SW: SWITCH ROTARY 12POS 2.5A 125V; Manufacturer Part Number: A11203RNCQ; Manufacturer: ITT C&K; Value: A11203; Throw Configuration: SP12T; Number of Positions: 12; Number of Poles per Deck: 1; Index Stops: Fixed; Index Angle: 30°; Electrical Life: 300000Cycles; Contact Style: Non-Shorting; Actuator Material: Nylon,Glass; Actuator Length: 9.53mm; Voltage Rating (DC): 125V; Voltage Rating (AC): 125V; Termination: PC Pins; Supplier Part Number: CKN10200-ND; Supplier: Digi-Key; Package Quantity: 1; Orientation: Straight; Number of Decks: 1; Mount: Through Hole,Panel; Min Operating Temperature: -30°C; Max Voltage Rating (DC): 125V; Max Voltage Rating (AC): 125V; Max Operating Temperature: 85°C; Max Current Rating: 2.5A; Library Name: MY_SWITCH.SchLib; Length: 26.19mm; Lead Free: Lead Free; Housing Material: Nylon; Depth: 26.19mm; Current Rating: 2.5A; Contact Plating: Silver; Contact Material: Silver; Component Kind: Standard
S104 = SW-SPST: SWITCH TOGGLE SPDT 5A 120V; Manufacturer Part Number: 100SP1T1B1M1QEH; Manufacturer: E-Switch; Voltage Rating (DC): 28V; Value: EG2350; Switch Function: On-On; Max Voltage Rating (AC): 250V; Illumination Type: Illuminated,Non-Illuminated; Electrical Life: 40000Cycles; Contact Resistance: 100mR; Actuator Type: Standard Round; Actuator Material: Brass,Chrome; Actuator Length: 10.41mm; Voltage Rating (AC): 120V; Throw Configuration: SPDT; Termination: Solder; Supplier Part Number: EG2350-ND; Supplier: Digi-Key; REACH SVHC: No SVHC; Packaging: Bulk; Package Quantity: 1; Orientation: Straight; Mount: Panel; Min Operating Temperature: -30°C; Max Voltage Rating (DC): 28V; Max Operating Temperature: 85°C; Library Name: Miscellaneous Devices.IntLib; Lead Free: Lead Free; Housing Material: Stainless Steel; Current Rating: 5A; Contact Plating: Silver; Contact Material: Copper Alloy,Silver; Component Kind: Standard
TP100-TP103 = TP-Round-Yellow: TP Thru-Hole; Manufacturer Part Number: Gold; Manufacturer: UW; Value: TP Thru-Hole; Supplier Part Number: Gold; Supplier: UW; Library Name: MY_TP.SchLib; Component Kind: Standard
TP104 TP105 = TP-Round-Black: TP Thru-Hole; Manufacturer Part Number: Gold; Manufacturer: UW; Value: TP Thru-Hole; Supplier Part Number: Gold; Supplier: UW; Library Name: MY_TP.SchLib; Component Kind: Standard
U101 = Dual OP Amp separte supplies: IC AUDIO AMP 2 CIRCUIT 8VSSOP; Manufacturer Part Number: OPA1678IDGKT; Manufacturer: Texas Instruments; Slew Rate: 9V/µs; Operating Supply Current: 2mA; Number of Circuits: 2; Input Offset Voltage (Vos): 500uV; Input Bias Current: 10pA; Gain Bandwidth Product: 16MHz; Value: OPA1678; Supplier Part Number: 296-46561-2-ND; Supplier: Digi-Key; Packaging: Tape and Reel; Number of Channels: 2; Mount: Surface Mount; Min Operating Temperature: -40°C; Max Operating Temperature: 85°C; Library Name: My_OpAmps.SchLib; Component Kind: Standard; Case/Package: VSSOP
U103 = Single OP Amp separate supply.: IC OPAMP AUDIO 11MHZ RRO 8SOIC; Manufacturer Part Number: OPA1641AIDR; Manufacturer: Texas Instruments; Voltage Gain: 134dB; Value: OPA1641AIIDR; Slew Rate: 20V/µs; Power Supply Rejection Ratio (PSRR): 137.08dB; Output Current per Channel: 36mA; Operating Supply Current: 1.8mA; Number of Elements: 1; Min Supply Voltage: 4.5V; Min Dual Supply Voltage: 2.25V; Max Supply Voltage: 36V; Max Dual Supply Voltage: 18V; Input Offset Voltage (Vos): 3.5mV; Input Bias Current: 2pA; Common Mode Rejection Ratio: 120dB; Unity Gain Bandwidth Product: 11MHz; Supplier Part Number: 296-48624-1-ND; Supplier: Digi-Key; Radiation Hardening: No; Packaging: Tape and Reel; Package Quantity: 2500; Number of Pins: 8; Number of Circuits: 1; Number of Channels: 1; Nominal Supply Current: 1.8mA; Nominal Gain Bandwidth Product: 11MHz; Min Operating Temperature: -40°C; Max Operating Temperature: 85°C; Library Name: My_OpAmps.SchLib; Lead Free: Lead Free; Gain Bandwidth Product: 11MHz; Component Kind: Standard; Case/Package: D
U104 = CD4028BM96: Decoder/Demultiplexer Single 4-to-10 16-Pin SOIC T/R; Manufacturer: ON Semiconductor; Vendor: Texas Instruments; Value: 4028; Turn-On Delay Time: 600ns; Supply Type: Single,Dual; Quiescent Current: 20uA; Polarity: Non-Inverting; Number of Outputs: 10; Number of Inputs: 4; Number of Elements: 1; Number of Circuits: 3; Min Supply Voltage: 3V; Max Supply Voltage: 18V; Low Level Output Current: 4.2mA; Logic Function: Decoder,Demux,Demultiplexer; High Level Output Current: -4.2mA; Built_By: EMA_UL_Team; Width: 4mm; Type: DEV; Supplier Part Number: MC14028BDR2GOSCT-ND; Supplier: Digi-Key; RefDes: RefDes; REACH SVHC: No SVHC; Radiation Hardening: No; Propagation Delay: 600ns; Packaging: Tape and Reel; Package Quantity: 2500; Number of Pins: 16; Number of Output Lines: 10; Number of Input Lines: 4; Number of Bits: 3; Mount: Surface Mount; Min Operating Temperature: -55°C; Max Operating Temperature: 125°C; Manufacturer Part Number: MC14028BDR2G; Library Name: MY_4028.SchLib; Length: 10mm; Lead Free: Lead Free; Independent Circuits: 1; Height: 1.5mm; Halogen Free: Halogen Free; Component Kind: Standard; Case/Package: SOIC; Copyright: Copyright (C) 2018 Accelerated Designs. All rights reserved
U105 = TLV2371_5SOT23: OPA172IDCKT 10 MHz,10 V/s, 4.5V to 36V, SC-70; Manufacturer Part Number: OPA172IDBVR; Manufacturer: Texas Instruments; Voltage Gain: 130dB; Slew Rate: 10V/µs; Power Supply Rejection Ratio (PSRR): -120dB; Output Current per Channel: 75mA; Operating Supply Current: 1.6mA; Number of Circuits: 1; Min Dual Supply Voltage: 2.25V; Max Dual Supply Voltage: 18V; Input Offset Voltage (Vos): 200uV; Input Bias Current: 8pA; Gain Bandwidth Product: 10MHz; Common Mode Rejection Ratio: 90dB; Value: OPA172; Supplier Part Number: 296-40433-1-ND; Supplier: Digi-Key; Simulation: TLV2371; REACH SVHC: No SVHC; Packaging: Tape and Reel; Number of Pins: 5; Number of Channels: 1; Number of Amplifiers: 1; Mount: Surface Mount; Min Supply Voltage: 4.5V; Min Operating Temperature: -40°C; Max Supply Voltage: 36V; Max Operating Temperature: 125°C; Lead Free: Lead Free; Contact Plating: Gold; Component Kind: Standard; Case/Package: SOT-23-5; Bandwidth: 10MHz; Library Name: TI-OPAMPS.SchLib
